# Altium schematic sheet amplifier_stage.SchDoc(amplifier_stage)
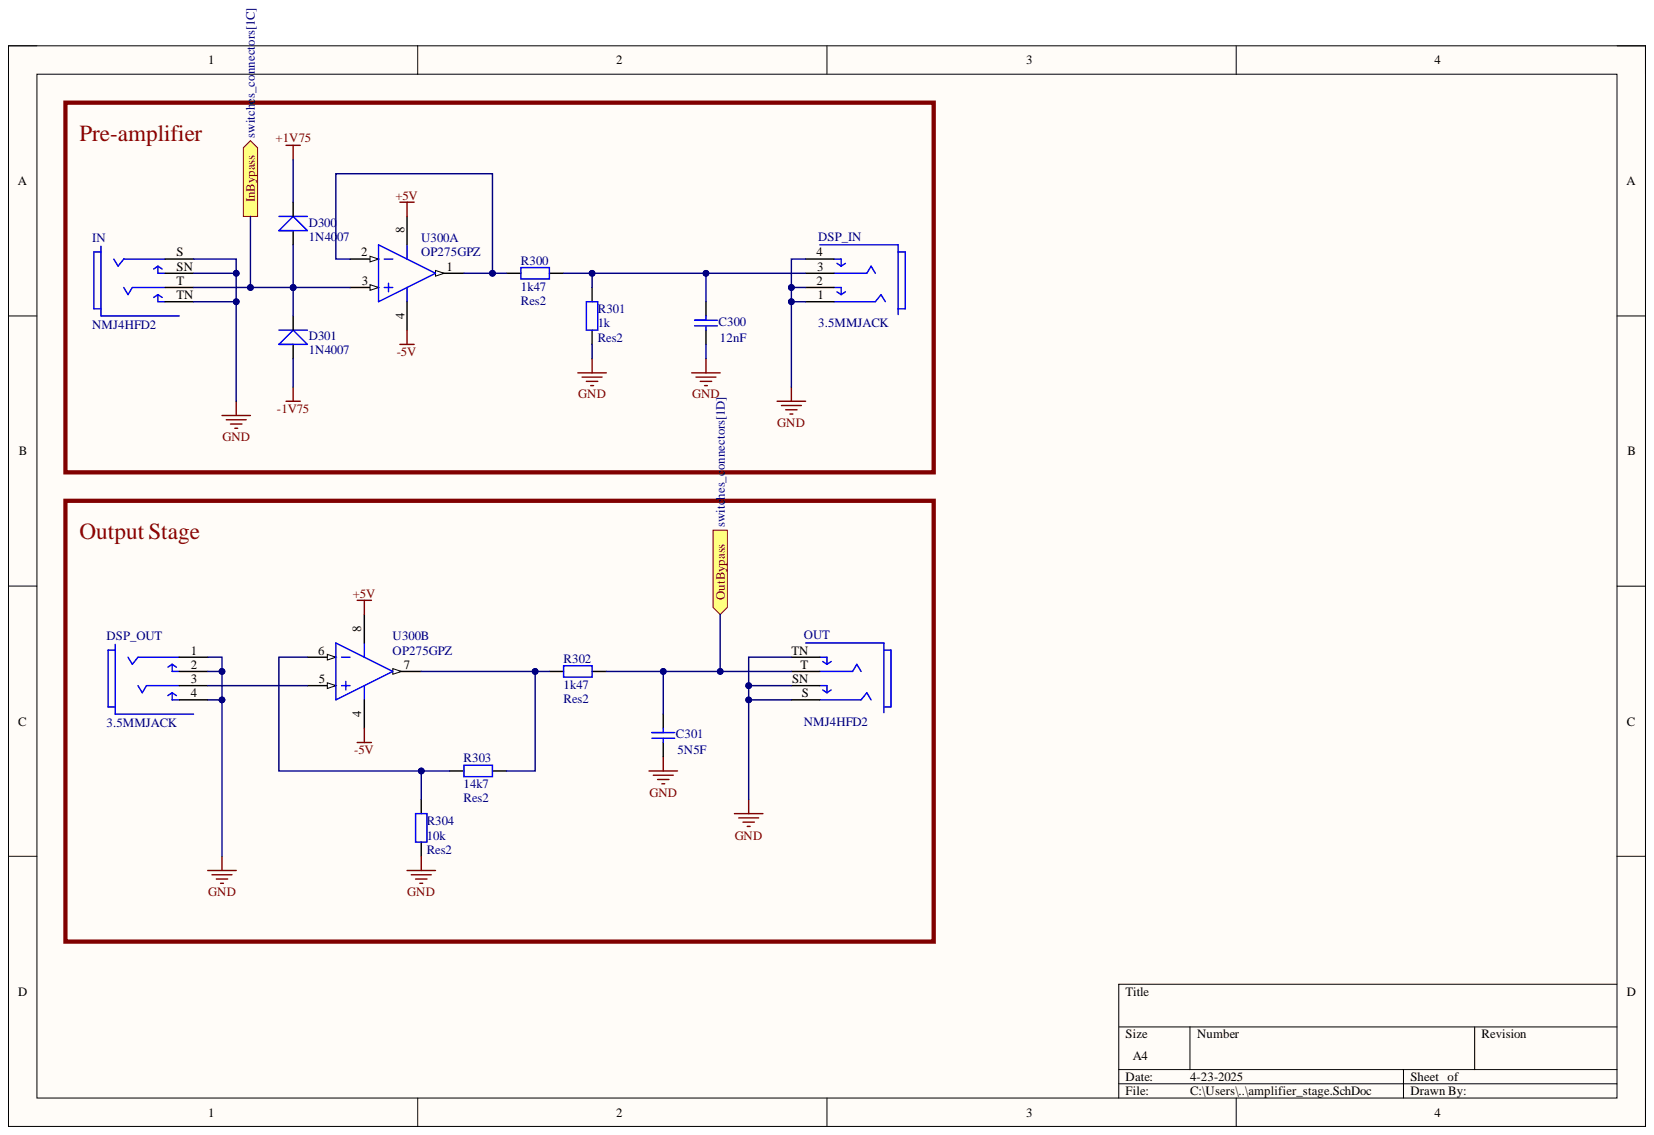
[diagram p0: amplifier_stage.SchDoc]

## Parts
C300  value 12nF  [Cap-1]  (hidden comment: Cap)
C301  value 5N5F  [Cap-1]  (hidden comment: Cap)
D300  1N4007  [1N4007]
D301  1N4007  [1N4007]
DSP_IN  3.5MMJACK  [3.5MMJACK]
DSP_OUT  3.5MMJACK  [3.5MMJACK]
IN  NMJ4HFD2  [FP-NMJ4HFD2-MFG]
OUT  NMJ4HFD2  [FP-NMJ4HFD2-MFG]
R300  Res2  value 1k47  [AXIAL-0.4 PCBK]
R301  Res2  value 1k  [AXIAL-0.4 PCBK]
R302  Res2  value 1k47  [AXIAL-0.4 PCBK]
R303  Res2  value 14k7  [AXIAL-0.4 PCBK]
R304  Res2  value 10k  [AXIAL-0.4 PCBK]
U300  OP275GPZ  [ADI-N-8]  (parts A,B)

## Ports
InBypass, OutBypass

## Nets
+1V75: D300.2
+5V: U300.8
-1V75: D301.1
-5V: U300.4
GND: C300.1 C301.1 DSP_IN.1 DSP_IN.2 DSP_IN.4 DSP_OUT.1 DSP_OUT.2 DSP_OUT.4 IN.S IN.SN IN.TN OUT.S OUT.SN OUT.TN R301.1 R304.1
InBypass: D300.1 D301.2 IN.T U300.3 ; port InBypass
OutBypass: C301.2 OUT.T R302.2 ; port OutBypass
NetC300_2: C300.2 DSP_IN.3 R300.2 R301.2
NetDSP_OUT_3: DSP_OUT.3 U300.5
NetR300_1: R300.1 U300.1 U300.2
NetR302_1: R302.1 R303.2 U300.7
NetR303_1: R303.1 R304.2 U300.6

## Library data of the parts
C300 C301 = Capacitor 1 space: Component Kind: Standard; Library Name: AAURacing.IntLib
IN OUT = CMP-78736-000001-1: Conn 1/4" Mono Jack F 3 POS Solder RA Thru-Hole 3 Terminal 1 Port; Manufacturer: Neutrik; Conn Orientation: Horizontal; Current Rating: 0.5A; Is Female: yes; Number Of Contacts: 2; Voltage Rating: 50V; Automotive: No; Category: Conn; Component Kind: Standard; ComponentLink2Description: Datasheet; ComponentLink2URL: https://www.neutrik.com/en/product/nmj4hfd2.pdf; Contact Material: Silver; Device Class L1: Connectors; Device Class L2: Audio / Video Connectors; Device Class L3: unset; Footprint Url: https://www.neutrik.com/media/8576/download/st-nmj4hfd2.pdf?v=1; Height: 15.89mm; Lead Free: Yes; Library Name: Altium Content Vault; Manufacturer Part Number: NMJ4HFD2; Mouser Description: Phone Audio Connector, Socket, 2, PCB Mount, Metal Body, Silver Plated Contacts; Octopart: https://octopart.com/nmj4hfd2-neutrik-758952; Package: 0; RoHS: TRUE; Temperature: -25°C to +70°C; Temperature Range High: +70°C; Temperature Range Low: -25°C
R300-R304 = Res PCBK: Resistor; Component Kind: Standard; LatestRevisionDate: 17-Jul-2002; LatestRevisionNote: Re-released for DXP Platform.; PackageReference: AXIAL-0.4; Published: 8-Jun-2000; Library Name: pcb_kursus_lib2020 (1).IntLib; Publisher: Altium Limited
U300 = CMP-0384-00207-1: Double Channel Excellent Sonic Characteristics Operational Amplifier, 9 MHz BW, 22 V/us SR, Industrial, 8-pin DIP (N-8), Tube; Generic Part Number: OP275; Manufacturer: Analog Devices; Amplifiers Per Package: 2; Input Bias Current: 100nA; Input Offset Voltage: 1mV; Iq/Amp: 2.5mA; Slew Rate: 22V/us; Small Signal Bandwidth: 9MHz; V Span Max: 44V; V Span Min: 9V; Code_JEDEC: MS-001; Component Kind: Standard; ComponentLink1Description: Manufacturer URL; ComponentLink1URL: http://www.analog.com/en/index.html; ComponentLink2Description: Datasheet; ComponentLink2URL: http://www.analog.com/static/imported-files/data_sheets/OP275.pdf; ComponentLink3Description: Package Specification; ComponentLink3URL: http:/www.analog.com/static/imported-files/packages/PKG_PDF/PDIP(N)/N_8.pdf; DatasheetVersion: Rev. C; Library Name: Altium Content Vault; Mounting Technology: Through Hole; PackageDescription: 8-Pin Plastic Dual In-Line Package, Row spacing 7.62 mm, Pitch 2.54 mm; PackageReference: N-8; PackageVersion: 070606-A; PartNumber: OP275GPZ
D300 D301 = 1N4007: Component Kind: Standard; Library Name: mit_seje_library.IntLib
DSP_IN DSP_OUT = 3.5MMJACK: Component Kind: Standard; Library Name: mit_seje_library.IntLib
